# Altium schematic sheet Course.SchDoc(Course)
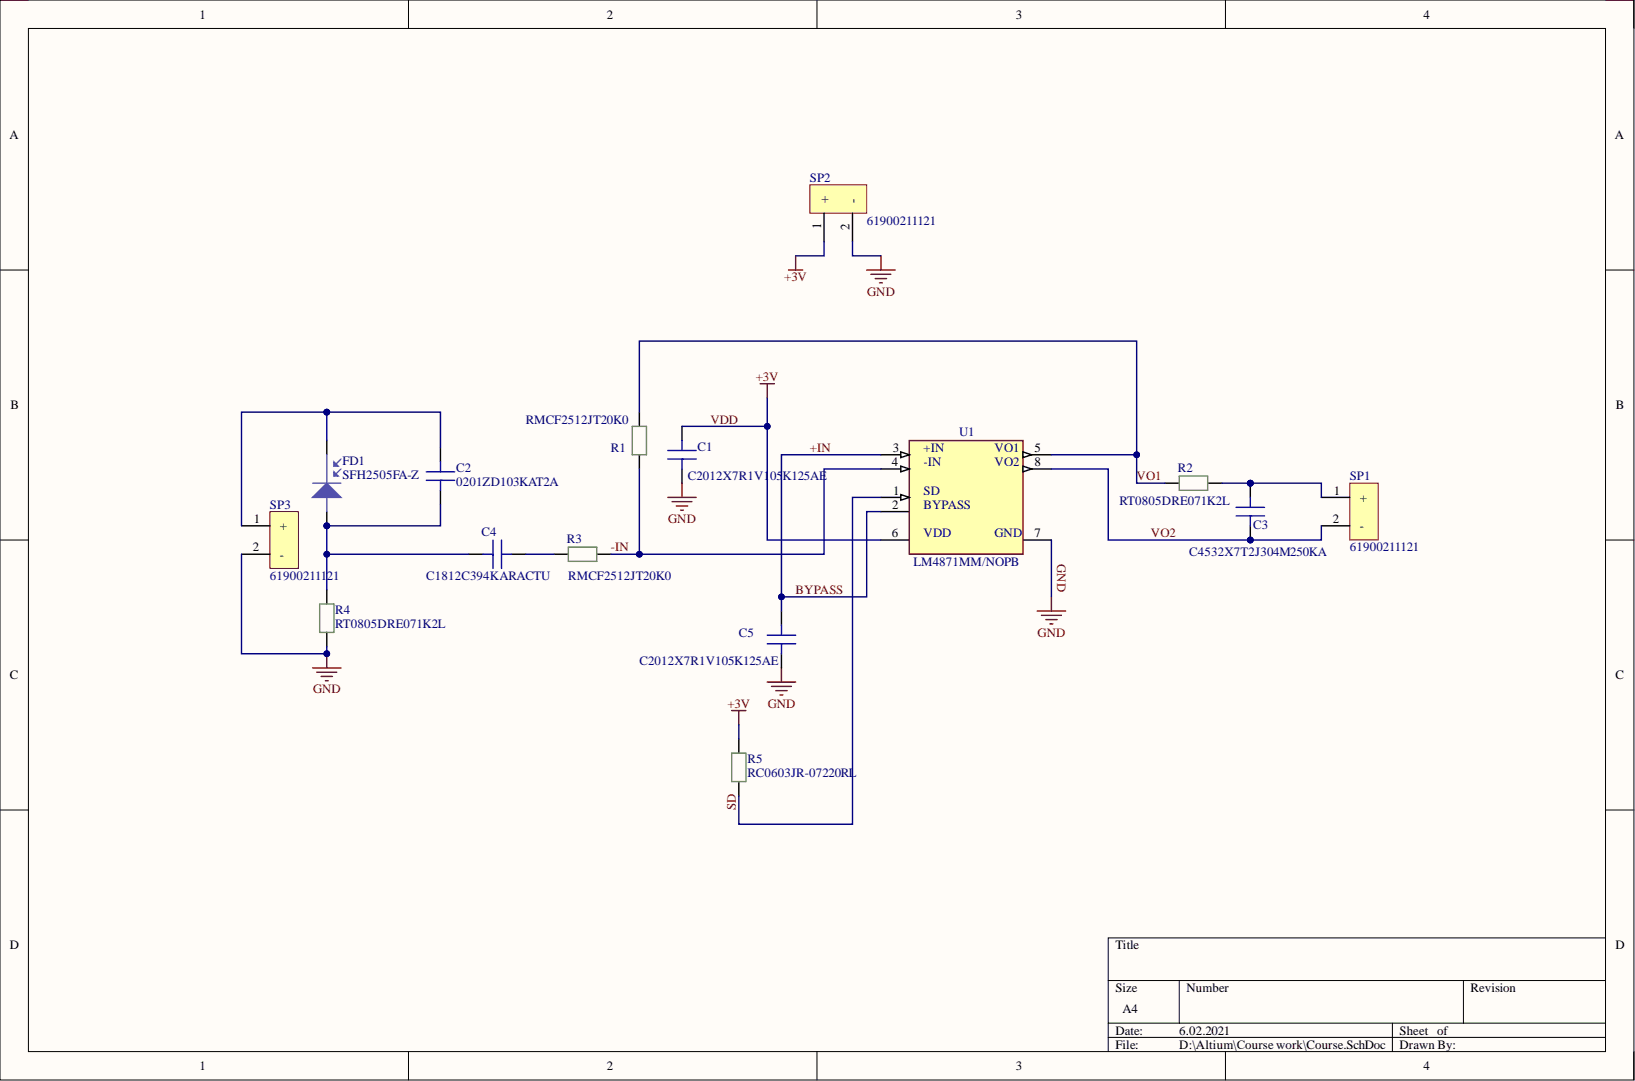
[diagram p0: Course.SchDoc]

## Parts
C1  C2012X7R1V105K125AE  [2012 Capacitor]
C2  0201ZD103KAT2A  [0201 Capacitor]
C3  C4532X7T2J304M250KA  [4532 Capacitor]
C4  C1812C394KARACTU  [1812 Capacitor]
C5  C2012X7R1V105K125AE  [2012 Capacitor]
FD1  SFH2505FA-Z  [FD]
R1  RMCF2512JT20K0  [2512 Resistor]
R2  RT0805DRE071K2L  [0805 Resistor]
R3  RMCF2512JT20K0  [2512 Resistor]
R4  RT0805DRE071K2L  [0805 Resistor]
R5  RC0603JR-07220RL  [0603 Resistor - duplicate]
SP1  61900211121  [Header]
SP2  61900211121  [Header]
SP3  61900211121  [Header]
U1  LM4871MM/NOPB  [SOP65P490X110-8N]

## Nets
+IN: C5.2 U1.2(BYPASS) U1.3(+IN)
-IN: R1.1 R3.2 U1.4
GND: C1.1 C5.1 R4.1 SP2.2 SP3.2 U1.7
SD: R5.1 U1.1
VDD: C1.2 R5.2 SP2.1 U1.6
VO1: R1.2 R2.1 U1.5(VO1)
VO2: C3.1 SP1.2 U1.8
NetC2_1: C2.1 C4.1 FD1.2 R4.2
NetC2_2: C2.2 FD1.1 SP3.1
NetC3_2: C3.2 R2.2 SP1.1
NetC4_2: C4.2 R3.1

## Library data of the parts
C1 C5 = C2012X7R1V105K125AE: CAP CER 1UF 35V X7R 0805; Manufacturer: TDK; CategoryC: Ceramic Capacitors; Tolerance: 10%; Capacitance: 1uF; Case Code (Imperial): 0805; Case Code (Metric): 2012; Case/Package: 0805; Category: Ceramic Capacitors; Component Kind: Standard; ComponentLink1Description: https://product.tdk.com/info/en/catalog/datasheets/mlcc_commercial_soft_en.pdf?src-supplier=Digi-Key; ComponentLink1URL: https://product.tdk.com/info/en/catalog/datasheets/mlcc_commercial_soft_en.pdf?src-supplier=Digi-Key; ComponentLink2Description: https://product.tdk.com/info/en/catalog/spec/mlccspec_commercial_soft_en.pdf?src-supplier=Digi-Key; ComponentLink2URL: https://product.tdk.com/info/en/catalog/spec/mlccspec_commercial_soft_en.pdf?src-supplier=Digi-Key; Features: Soft Termination; Length: 0.079inch; Library Name: Course_SchLib.SchLib; Manufacturer Part Number: C2012X7R1V105K125AE; Max Operating Temperature: 125°C; Min Operating Temperature: -55°C; Mount: Surface Mount; Packaging: Tape and Reel; Pricing 1: 1=0,53000, 10=0,37100, 50=0,25980, 100=0,22280, 500=0,16706, 1000=0,14108 (USD); RoHS: Yes; Stock 1: 2874; Supplier 1: Digi-Key; Supplier Part Number 1: 445-173849-1-ND; Thickness: 0.059inch; Voltage Rating: 35V; Width: 0.049inch
C2 = 0201ZD103KAT2A: Capacitor;  Ceramic;  Cap 0.01 uF;  Tol 10%;  Vol-Rtg 10 VDC;  SMT;  0201;  X5R; Manufacturer: Kyocera AVX; Capacitance: 10nF; CategoryC: Ceramic Capacitors; Voltage: 10V; Case Code (Imperial): 0201; Case Code (Metric): 0603; Case/Package: 0201; Category: Ceramic Capacitors; Component Kind: Standard; ComponentLink1Description: https://datasheet.ciiva.com/19467/734629-19467716.pdf?src-supplier=Jameco; ComponentLink1URL: https://datasheet.ciiva.com/19467/734629-19467716.pdf?src-supplier=Jameco; ComponentLink2Description: https://datasheet.ciiva.com/11788/0900766b80def1a5-11788485.pdf?src-supplier=RSComponents; ComponentLink2URL: https://datasheet.ciiva.com/11788/0900766b80def1a5-11788485.pdf?src-supplier=RSComponents; ComponentLink3Description: https://datasheet.ciiva.com/1123/84508-1123300.pdf?src-supplier=Element14; ComponentLink3URL: https://datasheet.ciiva.com/1123/84508-1123300.pdf?src-supplier=Element14; ComponentLink4Description: https://datasheet.ciiva.com/1123/84508-1123301.pdf?src-supplier=Element14; ComponentLink4URL: https://datasheet.ciiva.com/1123/84508-1123301.pdf?src-supplier=Element14; ComponentLink5Description: https://datasheet.ciiva.com/2990/cx5r-2990118.pdf?src-supplier=Avnet; ComponentLink5URL: https://datasheet.ciiva.com/2990/cx5r-2990118.pdf?src-supplier=Avnet; ComponentLink6Description: https://datasheet.ciiva.com/16300/cx5r-16300070.pdf?src-supplier=Future+Electronics; ComponentLink6URL: https://datasheet.ciiva.com/16300/cx5r-16300070.pdf?src-supplier=Future+Electronics; ComponentLink7Description: https://datasheet.ciiva.com/150/cx5r-150455.pdf?src-supplier=Digi-Key; ComponentLink7URL: https://datasheet.ciiva.com/150/cx5r-150455.pdf?src-supplier=Digi-Key; ComponentLink8Description: https://datasheet.ciiva.com/150/cx5r-150456.pdf?src-supplier=Digi-Key; ComponentLink8URL: https://datasheet.ciiva.com/150/cx5r-150456.pdf?src-supplier=Digi-Key; ComponentLink9Description: https://datasheet.ciiva.com/150/cx5r-150457.pdf?src-supplier=Digi-Key; ComponentLink9URL: https://datasheet.ciiva.com/150/cx5r-150457.pdf?src-supplier=Digi-Key; ComponentLink10Description: https://datasheet.ciiva.com/2987/cx5r-2987630.pdf?src-supplier=Avnet; ComponentLink10URL: https://datasheet.ciiva.com/2987/cx5r-2987630.pdf?src-supplier=Avnet; ComponentLink11Description: https://datasheet.ciiva.com/7693/1666675-7693438.pdf?src-supplier=Element14; ComponentLink11URL: https://datasheet.ciiva.com/7693/1666675-7693438.pdf?src-supplier=Element14; ComponentLink12Description: https://datasheet.ciiva.com/14590/70001028-14590302.pdf?src-supplier=Allied+Electronics; ComponentLink12URL: https://datasheet.ciiva.com/14590/70001028-14590302.pdf?src-supplier=Allied+Electronics; Construction: Flat; Contact Plating: Tin,Matte; Depth: 0.3mm; Dielectric: X5R; Dielectric Material: Ceramic; Height: 0.013mm; Lead Free: Lead Free; Length: 0.6mm; Library Name: Course_SchLib.SchLib; Manufacturer Part Number: 0201ZD103KAT2A; Max Operating Temperature: 85°C; Min Operating Temperature: -55°C; Mount: Surface Mount,PCB; Package Quantity: 1; Packaging: Tape and Reel; Pricing 1: 1=0,15000, 10=0,10600, 50=0,06040, 100=0,05000, 500=0,03508, 1000=0,02904, 2500=0,02702, 5000=0,02472 (USD); Radiation Hardening: No; REACH SVHC: No SVHC; RoHS: Yes; RoHS Compliant: Yes; Series: -; Stock 1: 330520; Supplier 1: Digi-Key; Supplier Part Number 1: 478-1051-1-ND; Termination: SMD/SMT; Thickness: 0.013inch; Tolerance: 10%; Voltage Rating: 10V; Voltage Rating (DC): 10V; Width: 0.3mm
C3 = C4532X7T2J304M250KA: CAP CER 0.3UF 630V X7T 1812; Manufacturer: TDK; Capacitance: 300nF; CategoryC: Ceramic Capacitors; Tolerance: 20%; Case Code (Imperial): 1812; Case Code (Metric): 4532; Case/Package: 1812; Category: Ceramic Capacitors; Component Kind: Standard; ComponentLink1Description: http://www.mouser.com/ds/2/400/lcc_commercial_highvoltage_en-844071.pdf?src-supplier=Mouser; ComponentLink1URL: http://www.mouser.com/ds/2/400/lcc_commercial_highvoltage_en-844071.pdf?src-supplier=Mouser; ComponentLink2Description: https://product.tdk.com/info/en/documents/chara_sheet/C4532X7T2J304M250KA.pdf?src-supplier=Digi-Key; ComponentLink2URL: https://product.tdk.com/info/en/documents/chara_sheet/C4532X7T2J304M250KA.pdf?src-supplier=Digi-Key; ComponentLink3Description: https://product.tdk.com/info/en/catalog/spec/mlccspec_commercial_general_midvoltage_en.pdf?src-supplier=Digi-Key; ComponentLink3URL: https://product.tdk.com/info/en/catalog/spec/mlccspec_commercial_general_midvoltage_en.pdf?src-supplier=Digi-Key; ComponentLink4Description: http://product.tdk.com/en/catalog/datasheets/mlcc_commercial_midvoltage_en.pdf?src-supplier=Avnet; ComponentLink4URL: http://product.tdk.com/en/catalog/datasheets/mlcc_commercial_midvoltage_en.pdf?src-supplier=Avnet; Dielectric: X7T; Height: 2.5mm; Length: 0.177inch; Library Name: Course_SchLib.SchLib; Manufacturer Part Number: C4532X7T2J304M250KA; Max Operating Temperature: 125°C; Min Operating Temperature: -55°C; Mount: Surface Mount; Package Quantity: 500; Packaging: Tape and Reel; Pricing 1: 1=1,38000, 10=1,08900, 50=0,94380, 100=0,81680 (USD); Resistor Type: Ceramic; RoHS: Yes; RoHS Compliant: Yes; Series: C; Stock 1: 797; Supplier 1: Digi-Key; Supplier Part Number 1: 445-13459-1-ND; Termination: SMD/SMT; Thickness: 0.11inch; Voltage Rating: 630V; Voltage Rating (DC): 630V; Width: 0.126inch
C4 = C1812C394KARACTU: Multilayer Ceramic Capacitors MLCC - SMD/SMT 250volts 0.39uF X7R 10%; Manufacturer: KEMET; Capacitance: 390nF; Voltage Rating: 250V; Case Code (Imperial): 1812; Case Code (Metric): 4532; Case/Package: 1812; Category: Ceramic Capacitors; CategoryC: Ceramic Capacitors; Component Kind: Standard; ComponentLink1Description: https://datasheet.ciiva.com/6276/kem-c1002-x7r-smd-6276293.pdf?src-supplier=Digi-Key; ComponentLink1URL: https://datasheet.ciiva.com/6276/kem-c1002-x7r-smd-6276293.pdf?src-supplier=Digi-Key; ComponentLink2Description: https://datasheet.ciiva.com/6276/kem-c1002-x7r-smd-6276285.pdf?src-supplier=Digi-Key; ComponentLink2URL: https://datasheet.ciiva.com/6276/kem-c1002-x7r-smd-6276285.pdf?src-supplier=Digi-Key; Dielectric Material: Ceramic; Length: 0.177inch; Library Name: Course_SchLib.SchLib; Manufacturer Part Number: C1812C394KARACTU; Max Operating Temperature: 125°C; Min Operating Temperature: -55°C; Mount: Surface Mount; Package Quantity: 1000; Packaging: Tape and Reel; Pricing 1: 1=1,11000, 10=0,87600, 50=0,75940, 100=0,65710, 500=0,49648 (USD); RoHS: Yes; RoHS Compliant: Yes; Series: C; Stock 1: 1345; Supplier 1: Digi-Key; Supplier Part Number 1: 399-7122-1-ND; Termination: SMD/SMT; Thickness: 0.065inch; Tolerance: 10%; Voltage Rating (DC): 250V; Width: 0.126inch
FD1 = SFH2505FA-Z: PHOTODIODE 900NM 5MM RADIAL; Manufacturer: Osram Opto; CategoryFD: Optical Sensors - Photodiodes; Dark Current: 100pA; Diode Type: PIN; Fall Time: 5ns; Max Power Dissipation: 100mW; Max Reverse Voltage (DC): 50V; Viewing Angle: 30°; Case/Package: Radial; Category: Photodiodes; Component Kind: Standard; ComponentLink1Description: https://datasheet.ciiva.com/4697/00042745-0-30737-4697696.pdf?src-supplier=Mouser; ComponentLink1URL: https://datasheet.ciiva.com/4697/00042745-0-30737-4697696.pdf?src-supplier=Mouser; ComponentLink2Description: https://datasheet.ciiva.com/2819/00083519-0-2819812.pdf?src-supplier=Digi-Key; ComponentLink2URL: https://datasheet.ciiva.com/2819/00083519-0-2819812.pdf?src-supplier=Digi-Key; ComponentLink3Description: https://datasheet.ciiva.com/2819/00083519-0-2819813.pdf?src-supplier=Digi-Key; ComponentLink3URL: https://datasheet.ciiva.com/2819/00083519-0-2819813.pdf?src-supplier=Digi-Key; Lead Free: Lead Free; Library Name: Course_SchLib.SchLib; Manufacturer Part Number: SFH2505FA-Z; Max Operating Temperature: 85°C; Min Operating Temperature: -40°C; Mount: Through Hole; Number of Pins: 2; Package Quantity: 1; Packaging: Tape and Reel; Pricing 1: 1=1,06000, 10=0,69200, 100=0,45320 (USD); Radiation Hardening: No; Response Time: 5ns; Reverse Voltage (DC): 50V; Rise Time: 5ns; RoHS: Yes; Stock 1: 5286; Supplier 1: Digi-Key; Supplier Part Number 1: 475-1436-1-ND; Wavelength: 900nm
R1 R3 = RMCF2512JT20K0: RES 20K OHM 5% 1W 2512; Manufacturer: Stackpole Electronics; CategoryR: Chip Resistor - Surface Mount; Resistance: 20kR; Temperature Coefficient: 200ppm/°C; Case/Package: 2512; Category: Chip SMD Resistors; Component Kind: Standard; ComponentLink1Description: https://www.seielect.com/catalog/SEI-RMCF_RMCP.pdf?src-supplier=Avnet; ComponentLink1URL: https://www.seielect.com/catalog/SEI-RMCF_RMCP.pdf?src-supplier=Avnet; ComponentLink2Description: https://www.seielect.com/catalog/sei-rmcf_rmcp.pdf?src-supplier=Digi-Key; ComponentLink2URL: https://www.seielect.com/catalog/sei-rmcf_rmcp.pdf?src-supplier=Digi-Key; Contact Plating: Tin,Matte; Features: Automotive AEC-Q200; Height: 0.028inch; Length: 0.248inch; Library Name: Course_SchLib.SchLib; Manufacturer Part Number: RMCF2512JT20K0; Max Operating Temperature: 155°C; Max Power Dissipation: 1W; Min Operating Temperature: -55°C; Mount: Surface Mount; Number of Terminations: 2; Package Quantity: 4000; Packaging: Tape and Reel; Power Rating: 1W; Pricing 1: 1=0,30000, 10=0,25300, 100=0,09890, 1000=0,04140 (USD); Radiation Hardening: No; Resistor Type: Thick Film; RoHS: Yes; RoHS Compliant: Yes; Series: RMCF; Stock 1: 2110; Supplier 1: Digi-Key; Supplier Part Number 1: RMCF2512JT20K0CT-ND; Tolerance: 5%; Width: 0.126inch
R2 R4 = RT0805DRE071K2L: RES SMD 1.2K OHM 0.5% 1/8W 0805; Manufacturer: Yageo; CategoryR: Chip Resistor - Surface Mount; Max Power Dissipation: 125mW; Resistance: 1.2kR; Temperature Coefficient: 50ppm/°C; Voltage Rating (DC): 150V; Case Code (Imperial): 0805; Case Code (Metric): 2012; Case/Package: 0805; Category: Chip SMD Resistors; Component Kind: Standard; ComponentLink1Description: https://datasheet.ciiva.com/2569/pyu-rt-1-to-0-05-rohs-l-5-2569287.pdf?src-supplier=Digi-Key; ComponentLink1URL: https://datasheet.ciiva.com/2569/pyu-rt-1-to-0-05-rohs-l-5-2569287.pdf?src-supplier=Digi-Key; Features: Moisture Resistant; Height: 0.024inch; Lead Free: Lead Free; Length: 0.079inch; Library Name: Course_SchLib.SchLib; Manufacturer Part Number: RT0805DRE071K2L; Max Operating Temperature: 155°C; Min Operating Temperature: -55°C; Number of Terminations: 2; Package Quantity: 5000; Packaging: Tape and Reel; Pricing 1: 1=0,12000, 10=0,11000, 100=0,04220, 1000=0,01855, 2500=0,01602 (USD); Resistor Type: Thin Film; RoHS: Yes; RoHS Compliant: Yes; Series: RT; Stock 1: 6723; Supplier 1: Digi-Key; Supplier Part Number 1: 311-2806-1-ND; Tolerance: 0.5%; Width: 0.049inch
R5 = RC0603JR-07220RL: YAGEO - RC0603JR-07220RL - SMD Chip Resistor, 0603 [1608 Metric], 220 ohm, RC Series, 75 V, Thick Film, 100 mW; CategoryR: Chip Resistor - Surface Mount; Max Power Dissipation: 100mW; Resistance: 220R; Resistance Tolerance: 5%; Temperature Coefficient: 100ppm/°C; Voltage Rating: 50V; Working Voltage: 75V; Case Code (Imperial): 0603; Case Code (Metric): 1608; Case/Package: 0603; Category: Chip SMD Resistors; Component Kind: Standard; ComponentLink1Description: https://datasheet.ciiva.com/46394/443971-46394998.pdf?src-supplier=Element14; ComponentLink1URL: https://datasheet.ciiva.com/46394/443971-46394998.pdf?src-supplier=Element14; Construction: Rectangular; Depth: 0.8mm; Features: Moisture Resistant; Lead Free: Lead Free; Length: 1.6mm; Library Name: Course_SchLib.SchLib; Manufacturer: Yageo; Manufacturer Part Number: RC0603JR-07220RL; Max Operating Temperature: 155°C; Military Standard: Not; Min Operating Temperature: -55°C; Number of Pins: 2; Number of Terminations: 2; Packaging: Tape and Reel; Power Rating: 100mW; Pricing 1: 1=0,10000, 10=0,02000, 100=0,00800, 1000=0,00360, 2500=0,00312 (USD); REACH SVHC: No SVHC; Resistor Type: Thick Film; RoHS: Yes; RoHS Compliant: Yes; Series: RC; Stock 1: 1909408; Supplier 1: Digi-Key; Supplier Part Number 1: 311-220GRCT-ND; Termination: SMD/SMT; Tolerance: 5%; Voltage Rating (DC): 50V; Width: 0.8mm
SP1-SP3 = 61900211121: Conn Wire to Board M 2 POS 2.54mm Solder ST Thru-Hole Bag; Manufacturer: Wurth Electronics; CategorySP: Connectors; Connector Type: Male Pin; Current Rating: 3A; Gender: Male; Insulation Resistance: 1GR; Number of Contacts: 2; Voltage Rating: 250V; Category: Headers and Wire Housings; Color: Beige; Component Kind: Standard; ComponentLink1Description: https://datasheet.ciiva.com/7631/1442200-7631329.pdf?src-supplier=Element14; ComponentLink1URL: https://datasheet.ciiva.com/7631/1442200-7631329.pdf?src-supplier=Element14; Contact Gender: Male; Contact Material: Copper Alloy,Copper; Contact Mating Length: 0.295inch; Contact Plating: Tin; Contact Type: Male Pin; Fastening Type: Friction; Flammability Rating: UL94 V-0; Height: 14.2mm; Housing Material: Nylon; Length: 5.8mm; Library Name: Course_SchLib.SchLib; Manufacturer Part Number: 61900211121; Max Operating Temperature: 105°C; Min Operating Temperature: -40°C; Mount: Through Hole; Number of Positions: 2; Number of Rows: 1; Package Quantity: 1000; Packaging: Bulk; Pitch: 2.54mm; Pricing 1: 1=0,53000, 10=0,45700, 50=0,41700, 100=0,33600, 500=0,30900, 1000=0,28200 (USD); REACH SVHC: Unknown; RoHS: Yes; RoHS Compliant: Yes; Series: WR-WTB; Stock 1: 962; Supplier 1: Digi-Key; Supplier Part Number 1: 732-2695-ND; Termination: Through Hole; Width: 5.12mm
U1 = LM4871MM/NOPB: IC AMP AUDIO PWR 3W MONO 8VSSOP; Manufacturer: TI National Semiconductor; Load Impedance: 3R; Max Supply Voltage: 5.5V; Min Supply Voltage: 2V; Nominal Supply Current: 6.5mA; Number of Channels: 1; Power Supply Rejection Ratio (PSRR): 60dB; Supply Type: Single; Case/Package: MSOP; Category: Amplifiers - Op Amps, Buffer, Instrumentation; Component Kind: Standard; ComponentLink1Description: https://datasheet.ciiva.com/25629/0900766b810fa56b-25629377.pdf?src-supplier=RSComponents; ComponentLink1URL: https://datasheet.ciiva.com/25629/0900766b810fa56b-25629377.pdf?src-supplier=RSComponents; ComponentLink2Description: https://datasheet.ciiva.com/1489/1761471-1489510.pdf?src-supplier=Farnell; ComponentLink2URL: https://datasheet.ciiva.com/1489/1761471-1489510.pdf?src-supplier=Farnell; ComponentLink3Description: https://datasheet.ciiva.com/1574/90021-1574134.pdf?src-supplier=Newark; ComponentLink3URL: https://datasheet.ciiva.com/1574/90021-1574134.pdf?src-supplier=Newark; ComponentLink4Description: https://datasheet.ciiva.com/1482/1834034-1482423.pdf?src-supplier=Element14; ComponentLink4URL: https://datasheet.ciiva.com/1482/1834034-1482423.pdf?src-supplier=Element14; ComponentLink5Description: https://datasheet.ciiva.com/6631/lm4871-6631436.pdf?src-supplier=Digi-Key; ComponentLink5URL: https://datasheet.ciiva.com/6631/lm4871-6631436.pdf?src-supplier=Digi-Key; ComponentLink6Description: https://datasheet.ciiva.com/6631/lm4871-6631437.pdf?src-supplier=Digi-Key; ComponentLink6URL: https://datasheet.ciiva.com/6631/lm4871-6631437.pdf?src-supplier=Digi-Key; ComponentLink7Description: https://datasheet.ciiva.com/6631/lm4871-6631438.pdf?src-supplier=Digi-Key; ComponentLink7URL: https://datasheet.ciiva.com/6631/lm4871-6631438.pdf?src-supplier=Digi-Key; Contact Plating: Tin; Height: 0.86mm; Lead Free: Lead Free; Length: 3mm; Library Name: Course_SchLib.SchLib; Manufacturer Part Number: LM4871MM/NOPB; Max Operating Temperature: 85°C; Max Output Power: 3W; Min Operating Temperature: -40°C; Mount: Surface Mount; Number of Pins: 8; Output Power: 3W; Package Quantity: 1000; Packaging: Tape and Reel; Pricing 1: 1=1,69000, 10=1,51300, 25=1,43640, 100=1,18000, 250=1,10304, 500=0,97478 (USD); Quiescent Current: 6.5mA; Radiation Hardening: No; REACH SVHC: No SVHC; RoHS: Yes; Stock 1: 5018; Supplier 1: Digi-Key; Supplier Part Number 1: LM4871MM/NOPBCT-ND; Termination: SMD/SMT; Weight: 0.01lb; Width: 3mm
